# Altium schematic sheet Topsheet.SchDoc
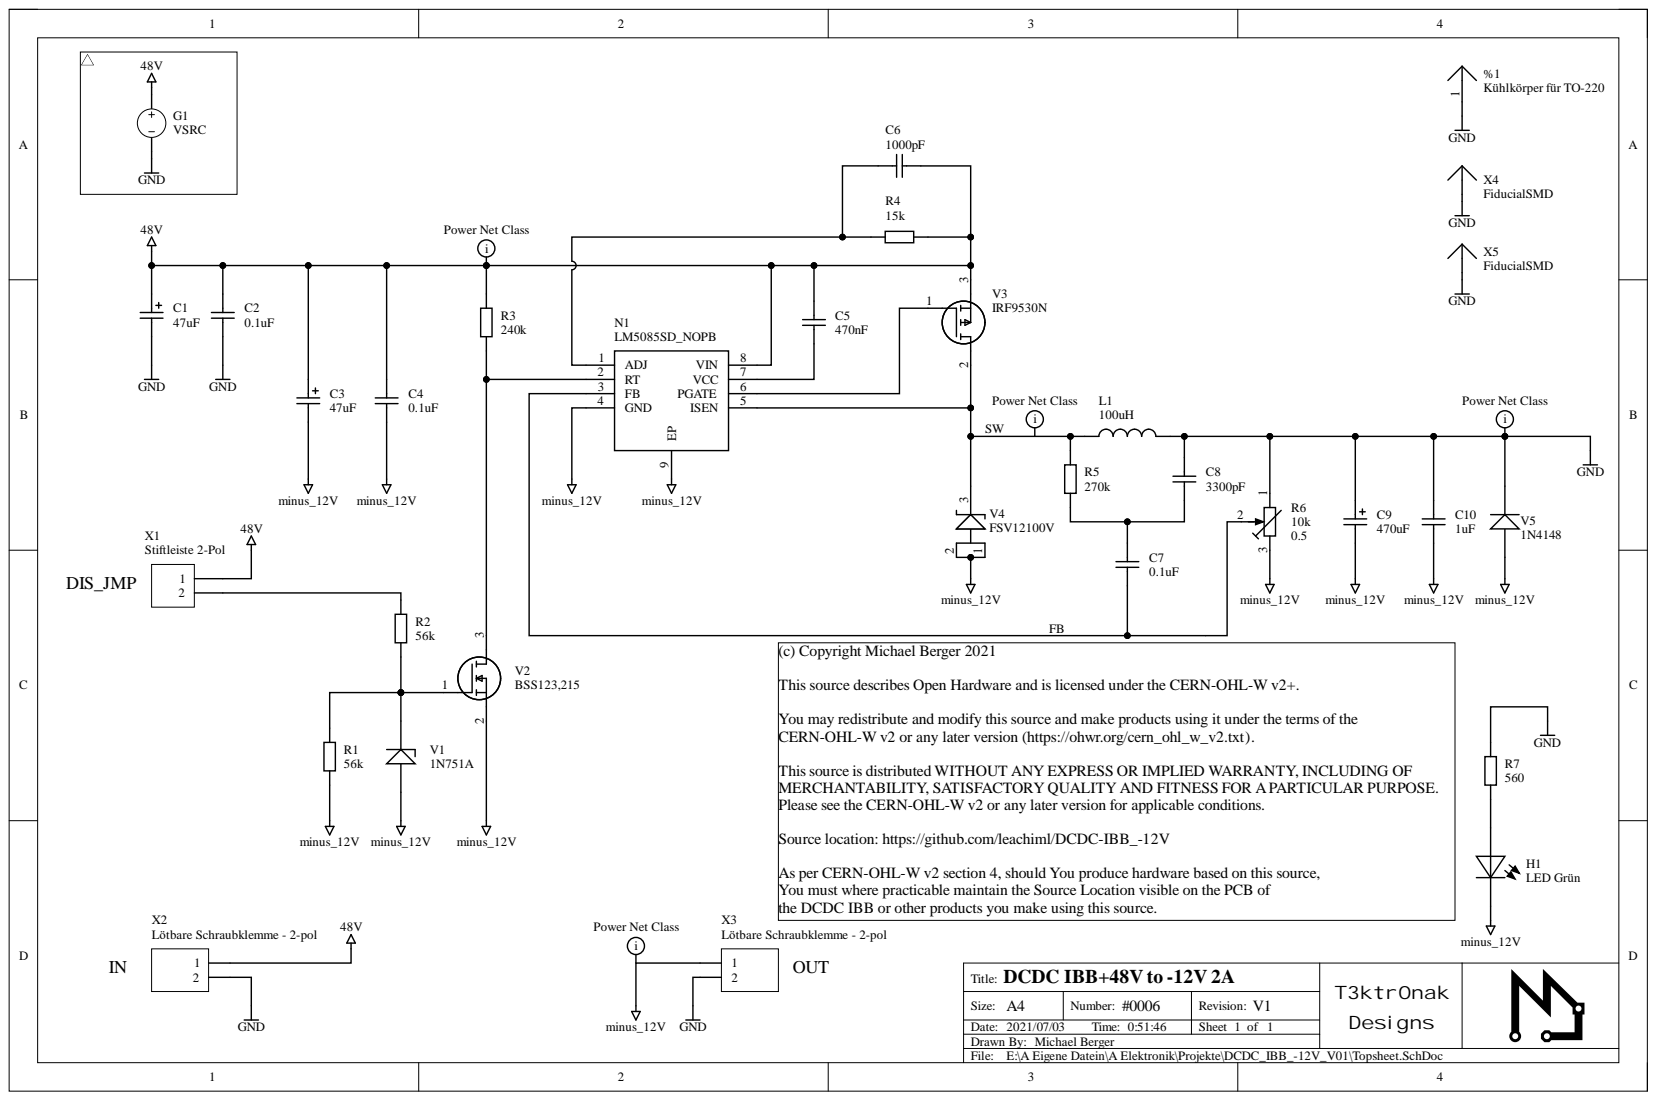
[diagram p0: Topsheet.SchDoc]

## Parts
%1  Kühlkörper für TO-220  [SK 104/25,4 STS]
C1  47uF  [WCAP-ATG5_D6.3H11]
C2  0.1uF  [C0805]
C3  47uF  [WCAP-ATG5_D6.3H11]
C4  0.1uF  [C0805]
C5  470nF  [C1206]
C6  1000pF  [C1206]
C7  0.1uF  [C0805]
C8  3300pF  [C1206]
C9  470uF  [WCAP-ATG5_D8H11.5]
C10  1uF  [C1206]
H1  LED Grün  [1206_Marked]
L1  100uH  [INDPM120120X1020N]
N1  LM5085SD_NOPB  [SON50P300X300X80-9N]
R1  56k  [C1206]
R2  56k  [C1206]
R3  240k  [C1206]
R4  15k  [C1206]
R5  270k  [C1206]
R6  10k  Set Position: 0.5  [64W-2,0M]
R7  560  [C1206]
V1  1N751A  [DO-35]
V2  BSS123,215  [SOT95P230X110-3N]
V3  IRF9530N  [TO254P469X1042X1967-3P]
V4  FSV12100V  [FSV1045V]
V5  1N4148  [DO-35]
X1  Stiftleiste 2-Pol  [HDR1X2]
X2  Lötbare Schraubklemme - 2-pol  [Terminal Block 2Pol RM5mm]
X3  Lötbare Schraubklemme - 2-pol  [Terminal Block 2Pol RM5mm]
X4  FiducialSMD  [PIN1RoundSMD]
X5  FiducialSMD  [PIN1RoundSMD]

## Nets
48V: C1.1 C2.2 C3.1 C4.2 C5.2 C6.1 N1.8(VIN) R3.2 R4.1 V3.3 X1.1 X2.1
GND: %1.1 C1.2 C2.1 C8.2 C9.1 C10.2 L1.2 R6.1 R7.2 V5.2 X2.2 X3.2 X4.1 X5.1
minus_12V: C3.2 C4.1 C9.2 C10.1 H1.K N1.4(GND) N1.9(EP) R1.1 R6.3 V1.1 V2.2 V4.1 V4.2 V5.1 X3.1
FB: C7.1 N1.3(FB) R6.2
SW: L1.1 N1.5(ISEN) R5.2 V3.2 V4.3
NetC5_1: C5.1 N1.7(VCC)
NetC6_2: C6.2 N1.1(ADJ) R4.2
NetC7_2: C7.2 C8.1 R5.1
NetH1_A: H1.A R7.1
NetN1_2: N1.2(RT) R3.1 V2.3
NetN1_6: N1.6(PGATE) V3.1
NetR1_2: R1.2 R2.1 V1.2 V2.1
NetR2_2: R2.2 X1.2

## Library data of the parts
%1 = Placeholder: Mechanische Komponenten Platzhalter; Manufacturer: Fischer Elektronik; Bezeichnung: Kühlkörper für TO-220; Component Kind: Standard; Orderingcode: SK 104/25,4 STS; Library Name: Template-Bauteile.SchLib
C1 C3 = 860020773013: WCAP-ATG5 Aluminum Electrolytic Capacitor, Radial, THT, D6.3xH11, 47uF, 63V; Manufacturer: Wurth Elektronik; Capacitance Tolerance: +/-20%; Dissipation Factor: 9%; Endurance: 2000h; Leakage Current: 29.61uA; Rated Voltage: 63VDC; Ripple Current: 125mA; Technical Reference: ATDD110470M063DSPA4B000; Bezeichnung: WCAP-ATG5 Aluminum Electrolytic Capacitor, Radial, THT, D6.3xH11, 47uF, 63V; Capacitance: 47uF; Component Kind: Standard; ComponentLink1Description: Manufacturer; ComponentLink1URL: http://www.we-online.de; ComponentLink2Description: Datasheet; ComponentLink2URL: https://www.we-online.com/catalog/datasheet/860020773013.pdf; Diameter: 6.3mm; Height: 11mm; Library Name: Altium_WCAP-ATG5_rev20b.IntLib; Mounting Technology: THT; Operating Temperature: -40 to +105degC; Orderingcode: 860020773013; Packaging: Ammo Pack; Published Date: October 2020; Simulation: ATG5_860020773013_47UF
C2 C4 C7 = Cap Semi: Capacitor (Semiconductor SIM Model); Manufacturer: KEMET; Bezeichnung: Kerko 63V; Orderingcode: C0805C104KMREC7210; Component Kind: Standard; LatestRevisionDate: 29-May-2009; LatestRevisionNote: IPC-7351 Footprint Added.; Library Name: Miscellaneous Devices.IntLib; PackageDescription: Chip Capacitor; PackageReference: C1206; Published: 8-Jun-2000; Signal Integrity: Cap Semi; Simulation: CAP; Value: 0.1uF; Publisher: Altium Limited
C5 = Cap Semi: Capacitor (Semiconductor SIM Model); Manufacturer: KEMET; Bezeichnung: Kerko 16V; Orderingcode: C1206C474KMREC7210; Component Kind: Standard; LatestRevisionDate: 29-May-2009; LatestRevisionNote: IPC-7351 Footprint Added.; Library Name: Miscellaneous Devices.IntLib; PackageDescription: Chip Capacitor; PackageReference: C1206; Published: 8-Jun-2000; Signal Integrity: Cap Semi; Simulation: CAP; Value: 470nF; Publisher: Altium Limited
C6 = Cap Semi: Capacitor (Semiconductor SIM Model); Manufacturer: KEMET; Bezeichnung: Kerko 63V; Orderingcode: C1206C102KMRECTU; Component Kind: Standard; LatestRevisionDate: 29-May-2009; LatestRevisionNote: IPC-7351 Footprint Added.; Library Name: Miscellaneous Devices.IntLib; PackageDescription: Chip Capacitor; PackageReference: C1206; Published: 8-Jun-2000; Signal Integrity: Cap Semi; Simulation: CAP; Value: 1000pF; Publisher: Altium Limited
C8 = Cap Semi: Capacitor (Semiconductor SIM Model); Manufacturer: KEMET; Bezeichnung: Kerko 63V; Orderingcode: C1206C332K1RAC7553; Component Kind: Standard; LatestRevisionDate: 29-May-2009; LatestRevisionNote: IPC-7351 Footprint Added.; Library Name: Miscellaneous Devices.IntLib; PackageDescription: Chip Capacitor; PackageReference: C1206; Published: 8-Jun-2000; Signal Integrity: Cap Semi; Simulation: CAP; Value: 3300pF; Publisher: Altium Limited
C9 = 860020374012: WCAP-ATG5 Aluminum Electrolytic Capacitor, Radial, THT, D8xH11.5, 470uF, 16V; Manufacturer: Wurth Elektronik; Capacitance Tolerance: +/-20%; Dissipation Factor: 15%; Endurance: 2000h; Leakage Current: 75.2uA; Rated Voltage: 16VDC; Ripple Current: 370mA; Technical Reference: ATDE115471M016DSPA7B000; Bezeichnung: WCAP-ATG5 Aluminum Electrolytic Capacitor, Radial, THT, D8xH11.5, 470uF, 16V; Capacitance: 470uF; Component Kind: Standard; ComponentLink1Description: Manufacturer; ComponentLink1URL: http://www.we-online.de; ComponentLink2Description: Datasheet; ComponentLink2URL: https://www.we-online.com/catalog/datasheet/860020374012.pdf; Diameter: 8mm; Height: 11.5mm; Library Name: Altium_WCAP-ATG5_rev20b.IntLib; Mounting Technology: THT; Operating Temperature: -40 to +105degC; Orderingcode: 860020374012; Packaging: Ammo Pack; Published Date: October 2020; Simulation: ATG5_860020374012_0R47MF
C10 = Cap Semi: Capacitor (Semiconductor SIM Model); Manufacturer: KEMET; Bezeichnung: Kerko 63V; Orderingcode: C1206C105K5RACTU; Component Kind: Standard; LatestRevisionDate: 29-May-2009; LatestRevisionNote: IPC-7351 Footprint Added.; Library Name: Miscellaneous Devices.IntLib; PackageDescription: Chip Capacitor; PackageReference: C1206; Published: 8-Jun-2000; Signal Integrity: Cap Semi; Simulation: CAP; Value: 1uF; Publisher: Altium Limited
H1 = LED 5mm, 2.54mm Rastermaß, 0.5mm: LED 5mm, 2.54mm Rastermaß, 0.5mm Beinchendurchmesser; Manufacturer: Stanley Electric; Bezeichnung: LED 20mA 1206 Grün; Orderingcode: RPG1102W-660-TR; Component Kind: Standard; Library Name: SamacSys.SchLib
L1 = Inductor: Manufacturer: Coilcraft; Bezeichnung: Spule 100uH; 3.4A; MSS1210-104KED; Code_IEC: 1005; Orderingcode: MSS1210-104KED; Code_JEITA: 0402; Component Kind: Standard; Description: Inductor; LatestRevisionDate: 29-May-2009; LatestRevisionNote: IPC-7351 Footprint Added.; Library Name: Miscellaneous Devices.IntLib; PackageDescription: Chip Inductor; PackageReference: 0402-A; Published: 8-Jun-2000; Signal Integrity: Inductor; Simulation: INDUCTOR; Value: 100uH; Publisher: Altium Limited
N1 = LM5085SD_NOPB: Integrated Circuit; Manufacturer_Name: Texas Instruments; Manufacturer_Part_Number: LM5085SD/NOPB; Arrow Part Number: LM5085SD/NOPB; Arrow Price/Stock: https://www.arrow.com/en/products/lm5085sdnopb/texas-instruments; Component Kind: Standard; Datasheet Link: http://www.ti.com/lit/gpn/lm5085; Height: 0.8mm; Mouser Part Number: 926-LM5085SD/NOPB; Mouser Price/Stock: https://www.mouser.co.uk/ProductDetail/Texas-Instruments/LM5085SD-NOPB?qs=QbsRYf82W3Ha5v5j3YN%2FqQ%3D%3D; Simulation: LM5085_TRANS; Library Name: T3ktr0nak_Bauteile_#1.IntLib
R1-R5 R7 = Res2: Resistor; Component Kind: Standard; LatestRevisionDate: 17-Jul-2002; LatestRevisionNote: Re-released for DXP Platform.; Library Name: Miscellaneous Devices.IntLib; PackageDescription: Axial Device, Thru-Hole; 2 Leads; 0.4 in Pin Spacing; PackageReference: AXIAL-0.4; Published: 8-Jun-2000; Signal Integrity: Res; Simulation: RESISTOR; Publisher: Altium Limited
  R1 R2: Value: 56k
  R3: Value: 240k
  R4: Value: 15k
  R5: Value: 270k
  R7: Value: 560
R6 = Präz-Poti: 64W-2,0M Präzisionspotentiometer, 25 Gänge, stehend, 2,0 MOhm; Manufacturer: Suntan; Bezeichnung: 64W-2,0M Präzisionspotentiometer, 25 Gänge, stehend, 2,0 MOhm; Component Kind: Standard; Simulation: Poti; Value: 10k; Library Name: T3ktr0nak_Bauteile_#1.IntLib
V1 = Diode 1N4148: Zener Diode 5,1V; Bezeichnung: Zener Diode 5,1V; Code_JEDEC: DO-204-AH; Component Kind: Standard; ComponentLink1Description: Manufacturer Link; ComponentLink1URL: http://www.fairchildsemi.com/; ComponentLink2Description: Datasheet; ComponentLink2URL: http://www.fairchildsemi.com/ds/1N%2F1N4148.pdf; DatasheetVersion: 1997; LatestRevisionDate: 17-Jul-2002; LatestRevisionNote: Re-released for DXP Platform.; Library Name: Miscellaneous Devices.IntLib; PackageDescription: Diode, Axial; Body 3.8 x 1.9 mm (LxDia. max), Lead Dia. 0.53 mm (max); PackageReference: DO-35; Published: 8-Jun-2000; Signal Integrity: 1N4148; Simulation: 1N4148; Publisher: Altium Limited
V2 = BSS123,215: MOSFET (N-Channel); Manufacturer_Name: Nexperia; Arrow Part Number: BSS123,215; Arrow Price/Stock: https://www.arrow.com/en/products/bss123215/nexperia; Component Kind: Standard; Datasheet Link: https://componentsearchengine.com/Datasheets/2/BSS123,215.pdf; Height: 1.1mm; Manufacturer_Part_Number: BSS123,215; Mouser Part Number: 771-BSS123-T/R; Mouser Price/Stock: https://www.mouser.co.uk/ProductDetail/Nexperia/BSS123215?qs=me8TqzrmIYWQISbOHJHs9Q%3D%3D; Simulation: BSS123; Library Name: T3ktr0nak_Bauteile_#1.IntLib
V3 = IRF9530N: MOSFET (P-Channel); Manufacturer_Name: International Rectifier; Component Kind: Standard; Datasheet Link: https://www.infineon.com/dgdl/irf9530n.pdf?fileId=5546d462533600a401535611b4a71dbf; Height: 4.69mm; Manufacturer_Part_Number: IRF9530N; Simulation: IRF9530; Library Name: T3ktr0nak_Bauteile_#1.IntLib
V4 = FSV12100V: Schottky Diode; Bezeichnung: 1N6263 Schottky Diode; Component Kind: Standard; Simulation: FSV12100V; Library Name: T3ktr0nak_Bauteile_#1.IntLib
V5 = Diode 1N4148: High Conductance Fast Diode; Bezeichnung: Kleinleistungs Diode; Code_JEDEC: DO-204-AH; Component Kind: Standard; ComponentLink1Description: Manufacturer Link; ComponentLink1URL: http://www.fairchildsemi.com/; ComponentLink2Description: Datasheet; ComponentLink2URL: http://www.fairchildsemi.com/ds/1N%2F1N4148.pdf; DatasheetVersion: 1997; LatestRevisionDate: 17-Jul-2002; LatestRevisionNote: Re-released for DXP Platform.; Library Name: Miscellaneous Devices.IntLib; Orderingcode: 1N4148; PackageDescription: Diode, Axial; Body 3.8 x 1.9 mm (LxDia. max), Lead Dia. 0.53 mm (max); PackageReference: DO-35; Published: 8-Jun-2000; Signal Integrity: 1N4148; Simulation: 1N4148; Publisher: Altium Limited
X1 = Header 2: Header, 2-Pin; Bezeichnung: Stiftleiste RM2,54; Component Kind: Standard; LatestRevisionDate: 17-Jul-2002; LatestRevisionNote: Re-released for DXP Platform.; Library Name: Miscellaneous Connectors.IntLib; Signal Integrity: Connector; Publisher: Altium Limited
X2 X3 = Terminal-Block 2Pol. RM5mm: Manufacturer: RND; Bezeichnung: Lötbare Schraubklemme - 2-pol, RM 5 mm, 90°; Orderingcode: RND 205-00045; Component Kind: Standard; Library Name: SamacSys.SchLib
X4 X5 = PlugRoundSMD: Component Kind: Standard; Description: PlugRoundSMD; LatestRevisionDate: 17-Jul-2002; LatestRevisionNote: Re-released for DXP Platform.; Signal Integrity: Connector; Library Name: LaborSymboleKUE_V3.IntLib; Publisher: Altium Limited
